# Altium schematic sheet Main.SchDoc(Main)
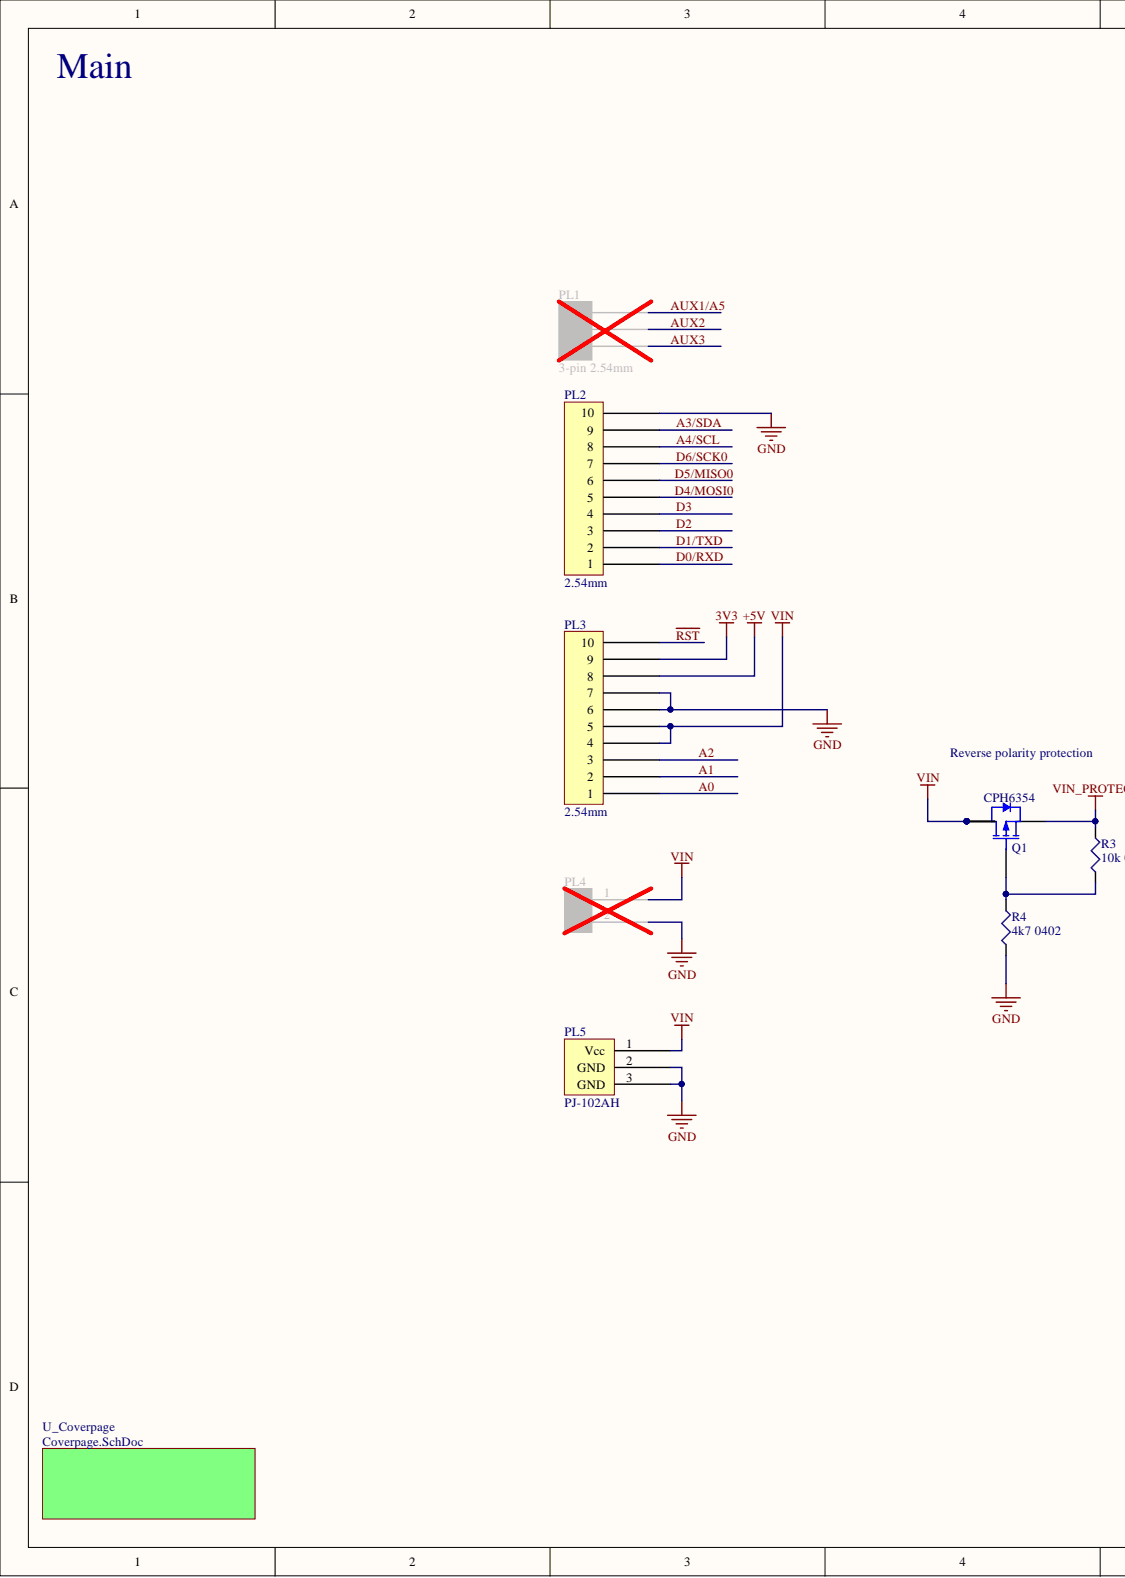
[diagram p0: Main.SchDoc, left]
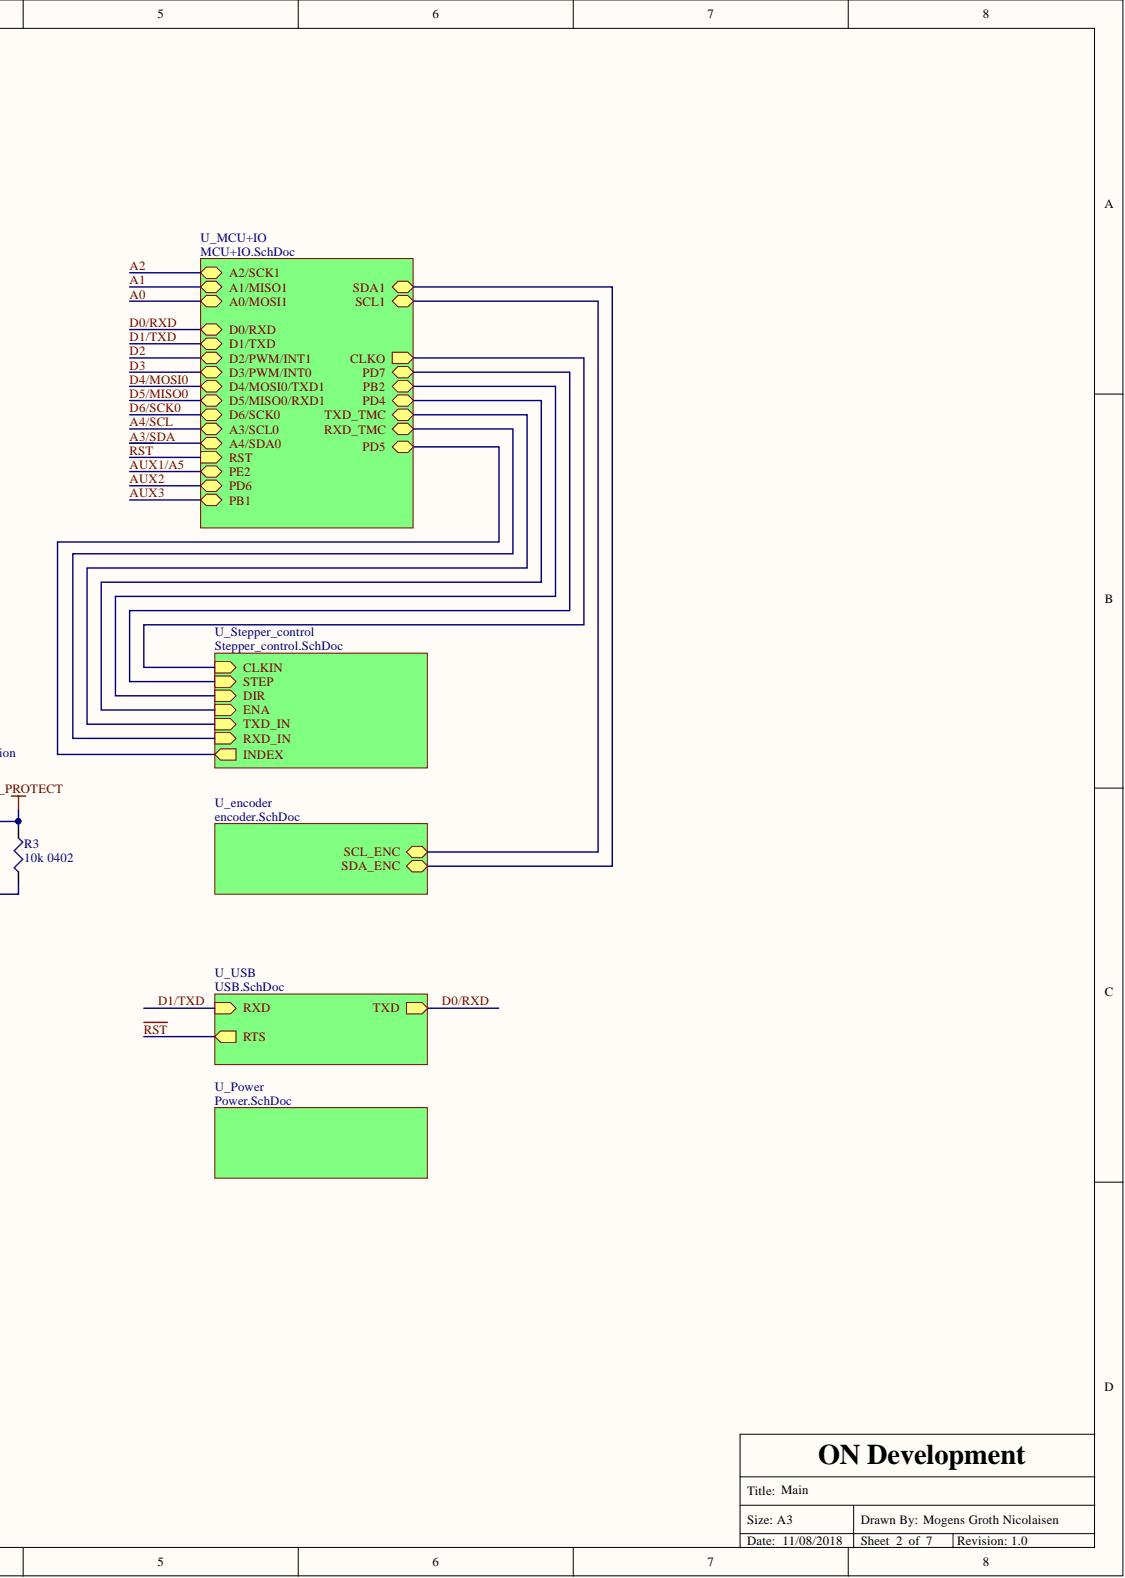
[diagram p1: Main.SchDoc, right]

## Parts
PL1  3-pin 2.54mm  [3-pin 2.54mm header]
PL2  2.54mm  [10-pin female 2.54mm]
PL3  2.54mm  [10-pin female 2.54mm]
PL4  2pin Terminal block 5.08mm  [5mm header]  (value not drawn)
PL5  PJ-102AH  [PJ-102AH]
Q1  CPH6354  [SOT95P280X95-6N]
R3  10k 0402  value 10k  Case/Package: 0402  [RES_0402]
R4  4k7 0402  value 4k7  Case/Package: 0402  [RES_0402]

## Nets
3V3: PL3.9
+5V: PL3.8
GND: PL2.10 PL3.6 PL3.7 PL4.2 PL5.2 PL5.3 R4.1
VIN: PL3.4 PL3.5 PL4.1 PL5.1 Q1.1 Q1.2 Q1.5 Q1.6
VIN_PROTECT: Q1.4 R3.2
~{RST}: PL3.10
A0: PL3.1
A1: PL3.2
A2: PL3.3
A3/SDA: PL2.9
A4/SCL: PL2.8
AUX1/A5: PL1.1
AUX2: PL1.2
AUX3: PL1.3
D0/RXD: PL2.1
D1/TXD: PL2.2
D2: PL2.3
D3: PL2.4
D4/MOSI0: PL2.5
D5/MISO0: PL2.6
D6/SCK0: PL2.7
NetQ1_3: Q1.3 R3.1 R4.2
CLKO:
PB2:
PD4:
PD5:
PD7:
RXD_TMC:
SCL_ENC:
SDA_ENC:
TXD_TMC:

## Library data of the parts
PL1 = CMP-003-0018-1: Pin header 3-pin 2.54mm male; Mfg. Part Number: DS1021-1*3SF1-1; LatestRevision: 1; DynamicData: Ningbo Connfly Electric DS1021-1*3SF1-1; Cat: Connectors; Library Name: ON Development Vault; FootprintRevisionID1: PCC-0050-2; FootprintName1: 3-pin 2.54mm header; Component Kind: Standard
PL2 PL3 = CMP-003-0004-2: Pin header 10-pin 2.54mm female; Mfg. Part Number: DS1023-1*10S21; Library Name: ON Development Vault; Component Kind: Standard
PL4 = CMP-003-0002-2: PCB terminal block, angled 90°; 5mm, 2-way, 1.5mm2; Mfg. Part Number: DG301-5.0-02P-11-00A(H); Library Name: ON Development Vault; Component Kind: Standard
PL5 = CMP-003-0001-3: CONN PWR JACK 2X5.5MM SOLDER; Mfg. Part Number: PJ-102AH; Library Name: ON Development Vault; Component Kind: Standard
Q1 = CMP-014-0006-2: MOSFET P-CH 60V 4A CPH6; Mfg. Part Number: CPH6354-TL-W; Library Name: ON Development Vault; Component Kind: Standard
R3 = CMP-001-0019-3: RES SMD 10K OHM 1% 1/16W 0402; Mfg. Part Number: RMCF0402FT10K0; Tolerance: 1 %; Power: 1/16 W; Library Name: ON Development Vault; Component Kind: Standard
R4 = CMP-001-0029-3: RES SMD 4.7K OHM 1% 1/16W 0402; Mfg. Part Number: RC1005F472CS; Tolerance: 1; Power: 1/16W; Library Name: ON Development Vault; Component Kind: Standard
